# Altium schematic sheet power_path.SchDoc(power_path)
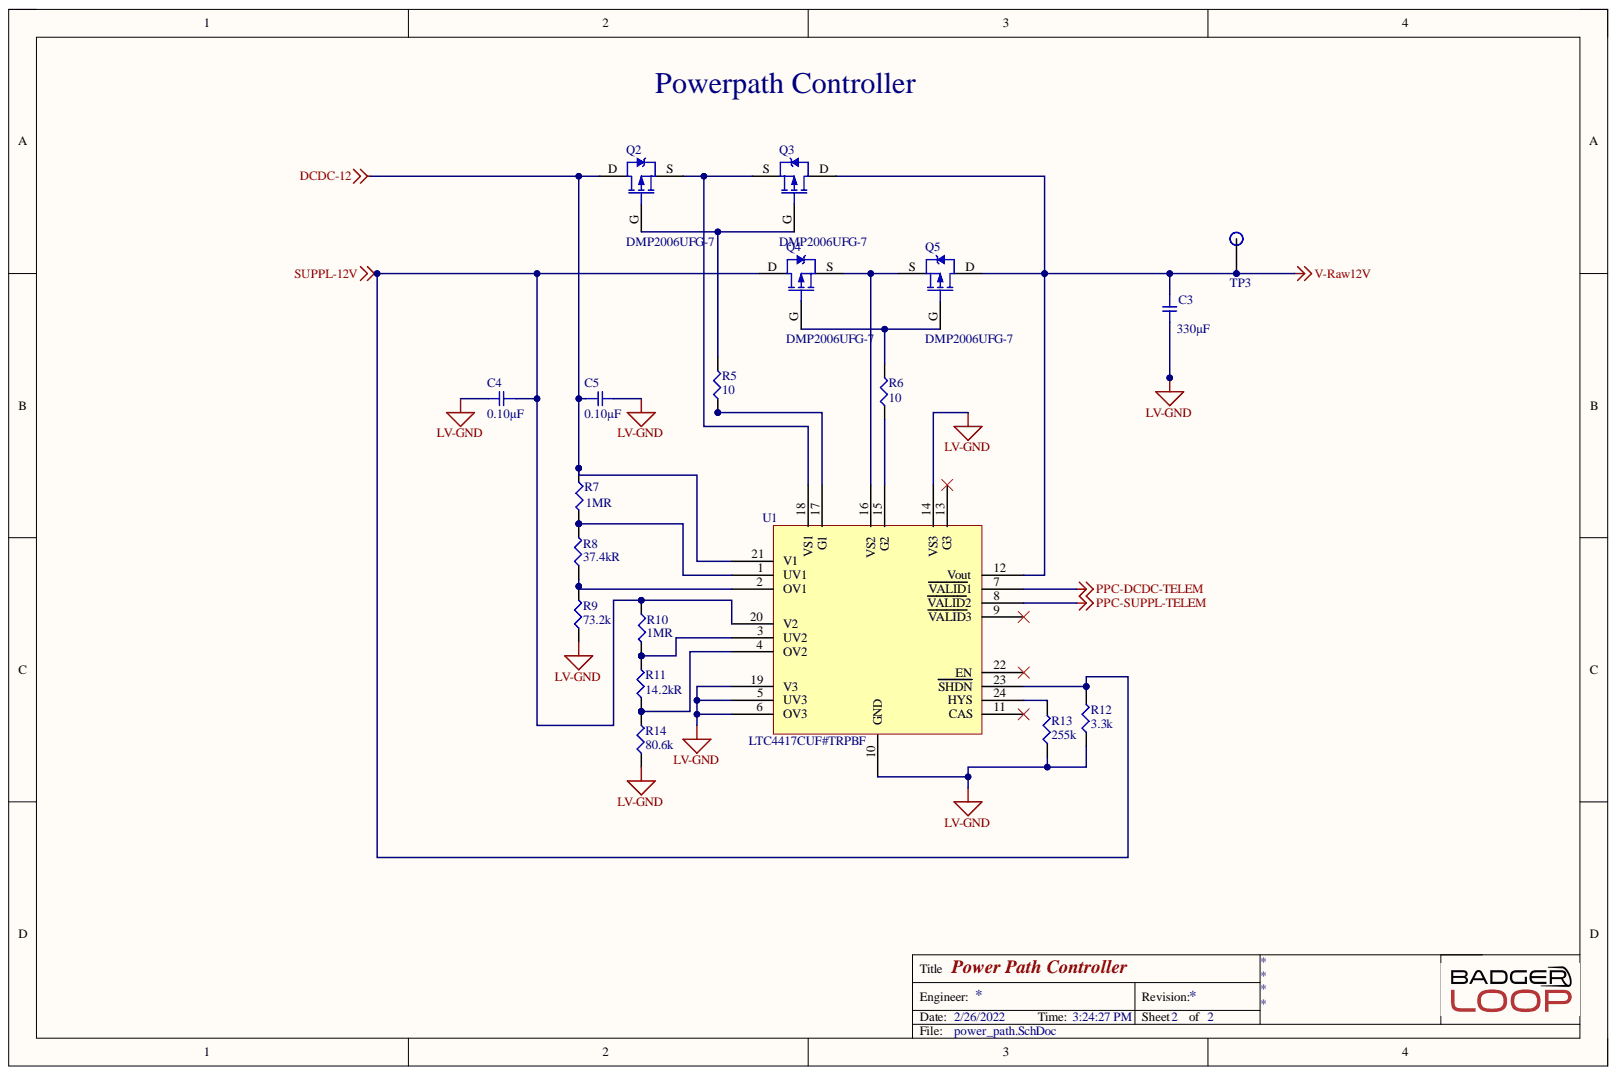
[diagram p0: power_path.SchDoc]

## Parts
C3  Capacitance: 330µF  [CAPAE110103X110N]  (hidden comment: GYB1E331MCW1GS)
C4  0.10µF  [SMD-0805C]
C5  0.10µF  [SMD-0805C]
Q2  DMP2006UFG-7  [DMP2006UFG]
Q3  DMP2006UFG-7  [DMP2006UFG]
Q4  DMP2006UFG-7  [DMP2006UFG]
Q5  DMP2006UFG-7  [DMP2006UFG]
R5  10  [SMD-0805-RES]
R6  10  [SMD-0805-RES]
R7  1MR  [SMD-0805-RES]
R8  37.4kR  [SMD-0805-RES]
R9  73.2k  [SMD-1206-RES]
R10  1MR  [SMD-0805-RES]
R11  14.2kR  [SMD-0805-RES]
R12  3.3k  [SMD-1206-RES]
R13  255k  [SMD-1206-RES]
R14  80.6k  [SMD-0805-RES]
TP3  TP  [Test Point]
U1  LTC4417CUF#TRPBF  [LT4417 QNF]

## Nets
DCDC-12: C5.1 Q2.D R7.1 U1.21(V1)
LV-GND: C3.2 C4.2 C5.2 R9.1 R12.1 R13.1 R14.1 U1.5(UV3) U1.6(OV3) U1.10(GND) U1.14(VS3) U1.19(V3)
PPC-DCDC-TELEM: U1.7(VALID1)
PPC-SUPPL-TELEM: U1.8(VALID2)
SUPPL-12V: C4.1 Q4.D R10.1 R12.2 U1.20(V2) U1.23(SHDN)
V-Raw12V: C3.1 Q3.D Q5.D TP3.1 U1.12(Vout)
NetQ2_G: Q2.G Q3.G R5.2
NetQ2_S: Q2.S Q3.S U1.18(VS1)
NetQ4_G: Q4.G Q5.G R6.2
NetQ4_S: Q4.S Q5.S U1.16(VS2)
NetR5_1: R5.1 U1.17(G1)
NetR6_1: R6.1 U1.15(G2)
NetR7_2: R7.2 R8.2 U1.1(UV1)
NetR8_1: R8.1 R9.2 U1.2(OV1)
NetR10_2: R10.2 R11.2 U1.3(UV2)
NetR11_1: R11.1 R14.2 U1.4(OV2)
NetR13_2: R13.2 U1.24(HYS)

Not connected: U1 9(VALID3) 11(CAS) 13(G3) 22(EN)

## Library data of the parts
C3 = GYB1E331MCW1GS: Aluminum Organic Polymer Capacitors 25V 330uf; Component Kind: Standard; ComponentLink1Description: Link; ComponentLink1URL: https://www.mouser.com/ProductDetail/Nichicon/GYB1E331MCW1GS?qs=sGAEpiMZZMvwFf0viD3Y3a3yb5D6sPUgteTcG6zlWVz7Qxll6G9Nxw%3D%3D; Library Name: capacitors.SchLib
C4 C5 = GCJ21BR71H104KA01L: CAP CER 0.1UF 50V X7R 0805; Manufacturer: Murata Electronics North America; @ qty: 0; Minimum Quantity: 1; Tolerance: ±10%; Unit Price (USD): 0.24; Applications: Automotive, Boardflex Sensitive; Component Kind: Standard; Datasheets: http://www.murata.com/~/media/webrenewal/support/library/catalog/products/capacitor/mlcc/c03e.ashx; Digi-Key Part Number: 490-5803-1-ND; Factory Stock: 0; Features: Soft Termination; Height - Seated (Max): -; Image: //media.digikey.com/Renders/Murata%20Renders/0805%20(2012%20Metric)%20.85mm.jpg; Lead Spacing: -; Lead Style: -; Library Name: capacitors.SchLib; Mounting Type: Surface Mount, MLCC; Operating Temperature: -55°C ~ 125°C; Package / Case: 0805 (2012 Metric); Packaging: Cut Tape (CT); Part Number: GCJ21BR71H104KA01L; Part Status: Active; Quantity Available: 700; Ratings: AEC-Q200; Series: GCJ; Size / Dimension: 0.079" L x 0.049" W (2.00mm x 1.25mm); Temperature Coefficient: X7R; Thickness (Max): 0.057" (1.45mm); Value: 0.10µF; Voltage: 50V
Q2-Q5 = DMP2006UFG-7: Power Field-Effect Transistor, 17.5A I(D), 20V, 0.0055ohm, 1-Element, P-Channel, Silicon, Metal-oxide Semiconductor FET; Manufacturer: Diodes; Category: MOSFETs; Component Kind: Standard; ComponentLink1Description: https://datasheet.ciiva.com:443/pdfs/VipMasterIC/IC/DIOD/DIOD-S-A0003133179/DIOD-S-A0003133179-1.pdf?src-supplier=IHS+Markit; ComponentLink1URL: https://datasheet.ciiva.com:443/pdfs/VipMasterIC/IC/DIOD/DIOD-S-A0003133179/DIOD-S-A0003133179-1.pdf?src-supplier=IHS+Markit; ComponentLink2Description: http://www.mouser.com/ds/2/115/DMP2006UFG-335633.pdf?src-supplier=Mouser; ComponentLink2URL: http://www.mouser.com/ds/2/115/DMP2006UFG-335633.pdf?src-supplier=Mouser; ComponentLink3Description: http://diodes.com/datasheets/DMP2006UFG.pdf?src-supplier=Avnet; ComponentLink3URL: http://diodes.com/datasheets/DMP2006UFG.pdf?src-supplier=Avnet; ComponentLink4Description: https://www.diodes.com/assets/Datasheets/DMP2006UFG.pdf?src-supplier=Digi-Key; ComponentLink4URL: https://www.diodes.com/assets/Datasheets/DMP2006UFG.pdf?src-supplier=Digi-Key; JESD-609 Code: e3; Library Name: misc.SchLib; Manufacturer Part Number: DMP2006UFG-7; Moisture Sensitivity Level: 1; Pricing 1: 20=0.73700, 100=0.51500, 500=0.46100, 1000=0.41100, 2500=0.37100 (USD); REACH SVHC: Yes; RoHS: Yes; RoHS Compliant: Yes; Stock 1: 1; Supplier 1: RSComponents; Supplier Part Number 1: 9211139P; Terminal Finish: Tin
R5 R6 = ERJ-6GEYJ100V: RES SMD 10 OHM 5% 1/8W 0805; Manufacturer: Panasonic Electronic Components; @ qty: 1500; Factory Stock: 0; Minimum Quantity: 1; Power (Watts): 0.125W, 1/8W; Temperature Coefficient: ±200ppm/°C; Unit Price (USD): 0.00496; Component Kind: Standard; Composition: Thick Film; Datasheets: http://industrial.panasonic.com/www-cgi/jvcr13pz.cgi?E+PZ+3+AOA0001+ERJ6GEYJ100V+7+WW; Digi-Key Part Number: P10ACT-ND; Failure Rate: -; Features: Automotive AEC-Q200; Height - Seated (Max): 0.028" (0.70mm); Image: //media.digikey.com/Renders/Panasonic%20Renders/ERJ-0805%20Pkg.jpg; Library Name: resistors.SchLib; Number of Terminations: 2; Operating Temperature: -55°C ~ 155°C; Package / Case: 0805 (2012 Metric); Packaging: Cut Tape (CT); Part Number: ERJ-6GEYJ100V; Part Status: Active; Quantity Available: 282033; Series: ERJ; Size / Dimension: 0.079" L x 0.049" W (2.00mm x 1.25mm); Supplier Device Package: 0805; Tolerance: ±5%; Value: 10
R7 R10 = CRCW08051M00FKEAC: RES 1M OHM 1% 1/8W 0805; Manufacturer: Vishay; Package Height: 0.5mm; Package Length: 2mm; Package Width: 1.25mm; Rated Power Dissipation (P): 125mW; Temperature Coefficient: -100100ppm/°C; Working Voltage: 150V; Category: Chip SMD Resistors; Component Kind: Standard; ComponentLink1Description: https://datasheet.ciiva.com:443/pdfs/VipMasterIC/IC/VISH/VISH-S-A0003599164/VISH-S-A0003599164-1.pdf?src-supplier=IHS+Markit; ComponentLink1URL: https://datasheet.ciiva.com:443/pdfs/VipMasterIC/IC/VISH/VISH-S-A0003599164/VISH-S-A0003599164-1.pdf?src-supplier=IHS+Markit; ComponentLink2Description: http://www.vishay.com/docs/28773/crcwce3.pdf?src-supplier=Digi-Key; ComponentLink2URL: http://www.vishay.com/docs/28773/crcwce3.pdf?src-supplier=Digi-Key; ComponentLink3Description: http://www.vishay.com/docs/20035/dcrcwe3.pdf?src-supplier=Avnet; ComponentLink3URL: http://www.vishay.com/docs/20035/dcrcwe3.pdf?src-supplier=Avnet; Construction: Chip; JESD-609 Code: e3; Library Name: resistors.SchLib; Manufacturer Part Number: CRCW08051M00FKEAC; Max Operating Temperature: 155°C; Min Operating Temperature: -55°C; Number of Pins: 2; Package Style: SMTMeter; Packaging: Tape and Reel; Pricing 1: 1=0.10000, 10=0.06300, 100=0.02540, 1000=0.01143, 2500=0.00992 (USD); REACH SVHC: Yes; Resistance: 1MR; Resistor Type: FIXED RESISTOR; RoHS: Yes; RoHS Compliant: Yes; Size Code: 0805; Stock 1: 15539; Supplier 1: Digi-Key; Supplier Part Number 1: 541-4135-1-ND; Technology: METAL GLAZE/THICK FILM; Terminal Finish: Nickel; Tolerance: 1%
R8 = CRCW080537K4FKEA: RES SMD 37.4K OHM 1% 1/8W 0805; Manufacturer: Vishay; Package Height: 0.45mm; Package Length: 2mm; Package Width: 1.25mm; Rated Power Dissipation (P): 125mW; Rated Temperature: 70°C; Reference Standard: AEC-Q200; Temperature Coefficient: 100ppm/°C; Working Voltage: 150V; Category: Chip SMD Resistors; Component Kind: Standard; ComponentLink1Description: https://datasheet.ciiva.com:443/pdfs/VipMasterIC/IC/VISH/VISH-S-A0007266705/VISH-S-A0007266705-1.pdf?src-supplier=IHS+Markit; ComponentLink1URL: https://datasheet.ciiva.com:443/pdfs/VipMasterIC/IC/VISH/VISH-S-A0007266705/VISH-S-A0007266705-1.pdf?src-supplier=IHS+Markit; ComponentLink2Description: https://datasheet.ciiva.com/9012/dcrcwe3-9012831.pdf?src-supplier=Avnet; ComponentLink2URL: https://datasheet.ciiva.com/9012/dcrcwe3-9012831.pdf?src-supplier=Avnet; ComponentLink3Description: https://datasheet.ciiva.com/7725/66915-7725943.pdf?src-supplier=Element14; ComponentLink3URL: https://datasheet.ciiva.com/7725/66915-7725943.pdf?src-supplier=Element14; ComponentLink4Description: https://datasheet.ciiva.com/22705/1697337-22705877.pdf?src-supplier=Element14; ComponentLink4URL: https://datasheet.ciiva.com/22705/1697337-22705877.pdf?src-supplier=Element14; ComponentLink5Description: https://datasheet.ciiva.com/22674/1912744-22674621.pdf?src-supplier=Element14; ComponentLink5URL: https://datasheet.ciiva.com/22674/1912744-22674621.pdf?src-supplier=Element14; ComponentLink6Description: https://datasheet.ciiva.com/7671/1697337-7671694.pdf?src-supplier=Element14; ComponentLink6URL: https://datasheet.ciiva.com/7671/1697337-7671694.pdf?src-supplier=Element14; ComponentLink7Description: https://datasheet.ciiva.com/7671/1697337-7671695.pdf?src-supplier=Element14; ComponentLink7URL: https://datasheet.ciiva.com/7671/1697337-7671695.pdf?src-supplier=Element14; ComponentLink8Description: https://datasheet.ciiva.com/16395/dcrcwe3-16395353.pdf?src-supplier=Future+Electronics; ComponentLink8URL: https://datasheet.ciiva.com/16395/dcrcwe3-16395353.pdf?src-supplier=Future+Electronics; ComponentLink9Description: https://datasheet.ciiva.com/2525/dcrcwe3-2525821.pdf?src-supplier=Digi-Key; ComponentLink9URL: https://datasheet.ciiva.com/2525/dcrcwe3-2525821.pdf?src-supplier=Digi-Key; ComponentLink10Description: https://datasheet.ciiva.com/2525/dcrcwe3-2525822.pdf?src-supplier=Digi-Key; ComponentLink10URL: https://datasheet.ciiva.com/2525/dcrcwe3-2525822.pdf?src-supplier=Digi-Key; ComponentLink11Description: https://datasheet.ciiva.com/2525/dcrcwe3-2525823.pdf?src-supplier=Digi-Key; ComponentLink11URL: https://datasheet.ciiva.com/2525/dcrcwe3-2525823.pdf?src-supplier=Digi-Key; Construction: Chip; JESD-609 Code: e3; Library Name: resistors.SchLib; Manufacturer Part Number: CRCW080537K4FKEA; Max Operating Temperature: 155°C; Min Operating Temperature: -55°C; Mount: Surface Mount; Number of Pins: 2; Package Shape: Rectangular; Package Style: SMTMeter; Packaging: Tape and Reel; Pricing 1: 1=0.10000, 10=0.06700, 100=0.02710, 1000=0.01219, 2500=0.01058 (USD); REACH SVHC: Yes; Resistance: 37.4kR; Resistor Type: FIXED RESISTOR; RoHS: Yes; RoHS Compliant: Yes; Size Code: 0805; Stock 1: 12211; Supplier 1: Digi-Key; Supplier Part Number 1: 541-37.4KCCT-ND; Technology: METAL GLAZE/THICK FILM; Terminal Finish: Nickel; Termination: Wraparound; Tolerance: 1%
R9 = ERJ-8ENF7322V: RES SMD 73.2K OHM 1% 1/4W 1206; Manufacturer: Panasonic Electronic Components; @ qty: 1500; Factory Stock: 0; Minimum Quantity: 1; Power (Watts): 0.25W, 1/4W; Temperature Coefficient: ±100ppm/°C; Unit Price (USD): 0.00933; Component Kind: Standard; Composition: Thick Film; Datasheets: http://industrial.panasonic.com/www-cgi/jvcr13pz.cgi?E+PZ+3+AOA0002+ERJ8ENF7322V+7+WW; Digi-Key Part Number: P73.2KFCT-ND; Failure Rate: -; Features: Automotive AEC-Q200; Height - Seated (Max): 0.028" (0.70mm); Image: //media.digikey.com/Renders/Panasonic%20Renders/ERJ-1206%20Pkg.jpg; Library Name: resistors.SchLib; Number of Terminations: 2; Operating Temperature: -55°C ~ 155°C; Package / Case: 1206 (3216 Metric); Packaging: Cut Tape (CT); Part Number: ERJ-8ENF7322V; Part Status: Active; Quantity Available: 19226; Series: ERJ; Size / Dimension: 0.126" L x 0.063" W (3.20mm x 1.60mm); Supplier Device Package: 1206; Tolerance: ±1%; Value: 73.2k
R11 = TNPW080514K2BEEA: RES 14.2K OHM 0.1% 1/5W 0805; Manufacturer: Vishay; Package Height: 0.45mm; Package Length: 2mm; Package Width: 1.25mm; Rated Power Dissipation (P): 125mW; Rated Temperature: 70°C; Reference Standard: AEC-Q200; Temperature Coefficient: 25ppm/°C; Working Voltage: 150V; Category: Chip SMD Resistors; Component Kind: Standard; ComponentLink1Description: https://datasheet.ciiva.com:443/pdfs/VipMasterIC/IC/VISH/VISH-S-A0002290253/VISH-S-A0002290253-1.pdf?src-supplier=IHS+Markit; ComponentLink1URL: https://datasheet.ciiva.com:443/pdfs/VipMasterIC/IC/VISH/VISH-S-A0002290253/VISH-S-A0002290253-1.pdf?src-supplier=IHS+Markit; ComponentLink2Description: http://www.mouser.com/ds/2/427/tnpw_e3-64594.pdf?src-supplier=Mouser; ComponentLink2URL: http://www.mouser.com/ds/2/427/tnpw_e3-64594.pdf?src-supplier=Mouser; ComponentLink3Description: http://www.vishay.com/docs/28758/tnpw_e3.pdf?src-supplier=Avnet; ComponentLink3URL: http://www.vishay.com/docs/28758/tnpw_e3.pdf?src-supplier=Avnet; ComponentLink4Description: http://www.vishay.com/docs/28758/tnpw_e3.pdf?src-supplier=Digi-Key; ComponentLink4URL: http://www.vishay.com/docs/28758/tnpw_e3.pdf?src-supplier=Digi-Key; ComponentLink5Description: http://www.vishay.com/docs/28758/tnpw_e3.pdf?src-supplier=Future+Electronics; ComponentLink5URL: http://www.vishay.com/docs/28758/tnpw_e3.pdf?src-supplier=Future+Electronics; Construction: Chip; Library Name: resistors.SchLib; Manufacturer Part Number: TNPW080514K2BEEA; Max Operating Temperature: 125°C; Min Operating Temperature: -55°C; Mount: Surface Mount; Number of Pins: 2; Package Style: SMTMeter; Packaging: Tape and Reel; Pricing 1: 1=0.75000, 10=0.54900, 50=0.54900, 100=0.35000, 1000=0.22100, 10000=0.21500 (USD); REACH SVHC: Yes; Resistance: 14.2kR; Resistor Type: FIXED RESISTOR; RoHS: Yes; RoHS Compliant: Yes; Size Code: 0805; Stock 1: 1469; Supplier 1: Mouser; Supplier Part Number 1: 71-TNPW080514K2BEEA; Technology: THIN FILM; Terminal Finish: Nickel; Termination: Wraparound; Tolerance: 0.1%
R12 = ERJ-8ENF3301V: RES SMD 3.3K OHM 1% 1/4W 1206; Manufacturer: Panasonic Electronic Components; @ qty: 1500; Factory Stock: 0; Minimum Quantity: 1; Power (Watts): 0.25W, 1/4W; Temperature Coefficient: ±100ppm/°C; Unit Price (USD): 0.00933; Component Kind: Standard; Composition: Thick Film; Datasheets: http://industrial.panasonic.com/www-cgi/jvcr13pz.cgi?E+PZ+3+AOA0002+ERJ8ENF3301V+7+WW; Digi-Key Part Number: P3.30KFCT-ND; Failure Rate: -; Features: Automotive AEC-Q200; Height - Seated (Max): 0.028" (0.70mm); Image: //media.digikey.com/Renders/Panasonic%20Renders/ERJ-1206%20Pkg.jpg; Library Name: resistors.SchLib; Number of Terminations: 2; Operating Temperature: -55°C ~ 155°C; Package / Case: 1206 (3216 Metric); Packaging: Cut Tape (CT); Part Number: ERJ-8ENF3301V; Part Status: Active; Quantity Available: 48879; Series: ERJ; Size / Dimension: 0.126" L x 0.063" W (3.20mm x 1.60mm); Supplier Device Package: 1206; Tolerance: ±1%; Value: 3.3k
R13 = ERJ-8ENF2553V: RES SMD 255K OHM 1% 1/4W 1206; Manufacturer: Panasonic Electronic Components; @ qty: 1500; Factory Stock: 0; Minimum Quantity: 1; Power (Watts): 0.25W, 1/4W; Temperature Coefficient: ±100ppm/°C; Unit Price (USD): 0.00933; Component Kind: Standard; Composition: Thick Film; Datasheets: http://industrial.panasonic.com/www-cgi/jvcr13pz.cgi?E+PZ+3+AOA0002+ERJ8ENF2553V+7+WW; Digi-Key Part Number: P255KFCT-ND; Failure Rate: -; Features: Automotive AEC-Q200; Height - Seated (Max): 0.028" (0.70mm); Image: //media.digikey.com/Renders/Panasonic%20Renders/ERJ-1206%20Pkg.jpg; Library Name: resistors.SchLib; Number of Terminations: 2; Operating Temperature: -55°C ~ 155°C; Package / Case: 1206 (3216 Metric); Packaging: Cut Tape (CT); Part Number: ERJ-8ENF2553V; Part Status: Active; Quantity Available: 49543; Series: ERJ; Size / Dimension: 0.126" L x 0.063" W (3.20mm x 1.60mm); Supplier Device Package: 1206; Tolerance: ±1%; Value: 255k
R14 = ERJ-6ENF8062V: RES SMD 80.6K OHM 1% 1/8W 0805; Manufacturer: Panasonic Electronic Components; @ qty: 1500; Factory Stock: 0; Minimum Quantity: 1; Power (Watts): 0.125W, 1/8W; Temperature Coefficient: ±100ppm/°C; Unit Price (USD): 0.00729; Component Kind: Standard; Composition: Thick Film; Datasheets: http://industrial.panasonic.com/www-cgi/jvcr13pz.cgi?E+PZ+3+AOA0002+ERJ6ENF8062V+7+WW; Digi-Key Part Number: P80.6KCCT-ND; Failure Rate: -; Features: Automotive AEC-Q200; Height - Seated (Max): 0.028" (0.70mm); Image: //media.digikey.com/Renders/Panasonic%20Renders/ERJ-0805%20Pkg.jpg; Library Name: resistors.SchLib; Number of Terminations: 2; Operating Temperature: -55°C ~ 155°C; Package / Case: 0805 (2012 Metric); Packaging: Cut Tape (CT); Part Number: ERJ-6ENF8062V; Part Status: Active; Quantity Available: 48051; Series: ERJ; Size / Dimension: 0.079" L x 0.049" W (2.00mm x 1.25mm); Supplier Device Package: 0805; Tolerance: ±1%; Value: 80.6k
TP3 = TP: SMD TEST POINT; Component Kind: Standard; Library Name: misc.SchLib
U1 = LTC4417CUF#TRPBF: Prioritized PowerPath Controller 24-Pin QFN EP T/R; Manufacturer: Analog Devices / Linear Technology; Operating Supply Current: 28uA; Turn-Off Delay Time: 700ns; Turn-On Delay Time: 1.4us; Case/Package: QFN; Category: Power Management ICs; Component Kind: Standard; ComponentLink1Description: http://components.arrow.com/part/dataview/56965737S396191N7433?src-supplier=Arrow+Electronics; ComponentLink1URL: http://components.arrow.com/part/dataview/56965737S396191N7433?src-supplier=Arrow+Electronics; ComponentLink2Description: http://cds.linear.com/docs/en/datasheet/4417f.pdf?src-supplier=Digi-Key; ComponentLink2URL: http://cds.linear.com/docs/en/datasheet/4417f.pdf?src-supplier=Digi-Key; ComponentLink3Description: http://www.linear.com/docs/42412?src-supplier=Digi-Key; ComponentLink3URL: http://www.linear.com/docs/42412?src-supplier=Digi-Key; Library Name: ic.SchLib; Max Operating Temperature: 70°C; Min Operating Temperature: 0°C; Number of Pins: 24; Package Quantity: 2500; Packaging: Tape and Reel; Pricing 1: 1=7.44000, 10=6.72300, 25=6.41040, 100=5.56610, 250=5.31592, 500=4.86750 (USD); RoHS: Yes; RoHS Compliant: Yes; Series: PowerPath™; Stock 1: 2733; Supplier 1: Digi-Key; Supplier Part Number 1: LTC4417CUF#TRPBFCT-ND
